# Altium schematic sheet main.SchDoc
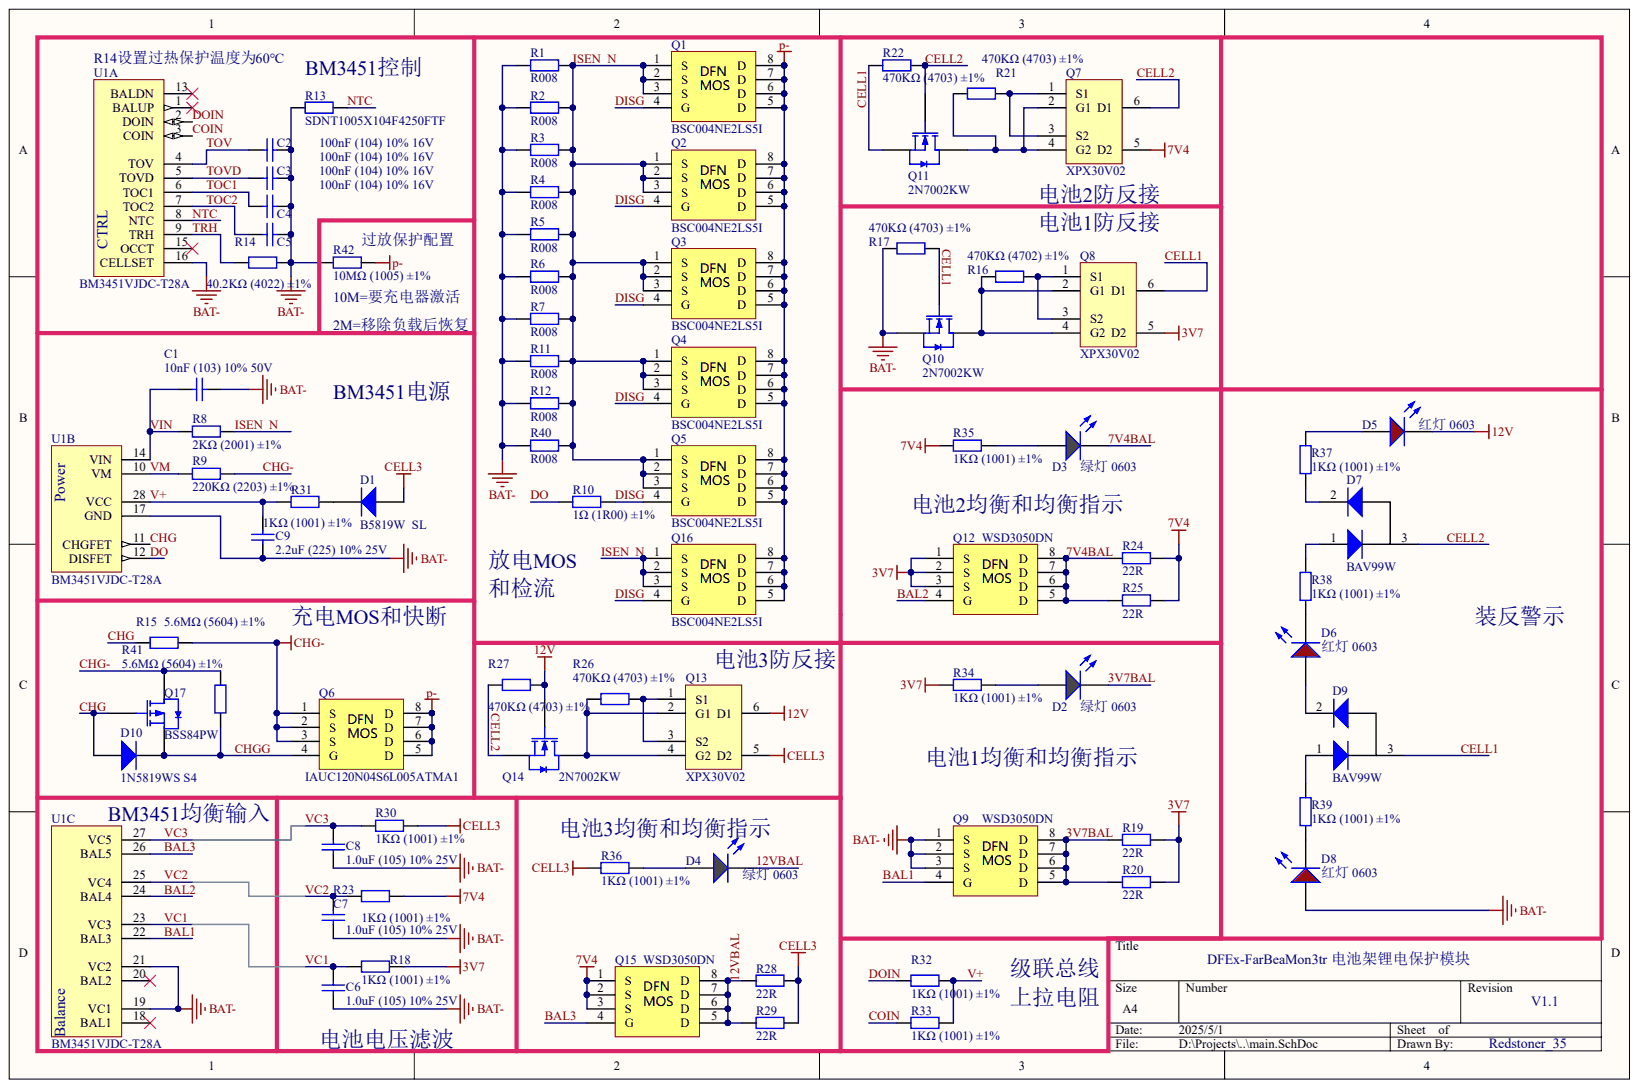
[diagram p0: main.SchDoc]

## Parts
C1  10nF (103) 10% 50V  [0402_C]
C2  100nF (104) 10% 16V  [0402_C]
C3  100nF (104) 10% 16V  [0402_C]
C4  100nF (104) 10% 16V  [0402_C]
C5  100nF (104) 10% 16V  [0402_C]
C6  1.0uF (105) 10% 25V  [0402_C]
C7  1.0uF (105) 10% 25V  [0402_C]
C8  1.0uF (105) 10% 25V  [0402_C]
C9  2.2uF (225) 10% 25V  [0402_C]
D1  B5819W  SL  [SOD-123]
D2  绿灯 0603  Package: 0603  [0603_LED_S1]
D3  绿灯 0603  Package: 0603  [0603_LED_S1]
D4  绿灯 0603  Package: 0603  [0603_LED_S1]
D5  红灯 0603  Package: 0603  [0603_LED_S1]
D6  红灯 0603  [0603_LED_S1]
D7  BAV99W  [SC70-3]
D8  红灯 0603  [0603_LED_S1]
D9  BAV99W  [SC70-3]
D10  1N5819WS S4  [SOD-323]
Q1  BSC004NE2LS5I  [SON/DFN5x6(MOS)]
Q2  BSC004NE2LS5I  [SON/DFN5x6(MOS)]
Q3  BSC004NE2LS5I  [SON/DFN5x6(MOS)]
Q4  BSC004NE2LS5I  [SON/DFN5x6(MOS)]
Q5  BSC004NE2LS5I  [SON/DFN5x6(MOS)]
Q6  IAUC120N04S6L005ATMA1  [SON/DFN5x6(MOS)]
Q7  XPX30V02  [EDFN3x3]
Q8  XPX30V02  [EDFN3x3]
Q9  WSD3050DN  [DFN-8_L3.0-W3.0-P0.65-BL]
Q10  2N7002KW  [SC70-3]
Q11  2N7002KW  [SC70-3]
Q12  WSD3050DN  [DFN-8_L3.0-W3.0-P0.65-BL]
Q13  XPX30V02  [EDFN3x3]
Q14  2N7002KW  [SC70-3]
Q15  WSD3050DN  [DFN-8_L3.0-W3.0-P0.65-BL]
Q16  BSC004NE2LS5I  [SON/DFN5x6(MOS)]
Q17  BSS84PW  [SC70-3]
R1  R008  [1206R]
R2  R008  [1206R]
R3  R008  [1206R]
R4  R008  [1206R]
R5  R008  [1206R]
R6  R008  [1206R]
R7  R008  [1206R]
R8  2KΩ (2001) ±1%  [0402_R]
R9  220KΩ (2203) ±1%  [0402_R]
R10  1Ω (1R00) ±1%  [0402_R]
R11  R008  [1206R]
R12  R008  [1206R]
R13  SDNT1005X104F4250FTF  [0402_R]
R14  40.2KΩ (4022) ±1%  [0402_R]
R15  5.6MΩ (5604) ±1%  [0402_R]
R16  470KΩ (4702) ±1%  [0402_R]
R17  470KΩ (4703) ±1%  [0402_R]
R18  1KΩ (1001) ±1%  [0402_R]
R19  22R  [1210R]
R20  22R  [1210R]
R21  470KΩ (4703) ±1%  [0402_R]
R22  470KΩ (4703) ±1%  [0402_R]
R23  1KΩ (1001) ±1%  [0402_R]
R24  22R  [1210R]
R25  22R  [1210R]
R26  470KΩ (4703) ±1%  [0402_R]
R27  470KΩ (4703) ±1%  [0402_R]
R28  22R  [1210R]
R29  22R  [1210R]
R30  1KΩ (1001) ±1%  [0402_R]
R31  1KΩ (1001) ±1%  [0402_R]
R32  1KΩ (1001) ±1%  [0402_R]
R33  1KΩ (1001) ±1%  [0402_R]
R34  1KΩ (1001) ±1%  [0402_R]
R35  1KΩ (1001) ±1%  [0402_R]
R36  1KΩ (1001) ±1%  [0402_R]
R37  1KΩ (1001) ±1%  [0402_R]
R38  1KΩ (1001) ±1%  [0402_R]
R39  1KΩ (1001) ±1%  [0402_R]
R40  R008  [1206R]
R41  5.6MΩ (5604) ±1%  [0402_R]
R42  10MΩ (1005) ±1%  [0402_R]
U1  BM3451VJDC-T28A  [TSSOP28-BM3451]  (parts A,B,C)

## Nets
3V7: Q8.5(D2) Q12.1(S) Q12.2(S) Q12.3(S) R18.2 R19.1 R20.1 R34.1
7V4: Q7.5(D2) Q15.1(S) Q15.2(S) Q15.3(S) R23.2 R24.1 R25.1 R35.1
12V: D5.K Q13.6(D1) Q14.1 R27.2
BAT-: C1.2 C2.2 C3.2 C4.2 C5.2 C6.1 C7.1 C8.1 C9.1 D8.A Q9.1(S) Q9.2(S) Q9.3(S) Q10.2 R1.2 R2.2 R3.2 R4.2 R5.2 R6.2 R7.2 R11.2 R12.2 R13.2 R14.2 R17.1 R40.2 R42.1 U1.16(CELLSET) U1.17(GND) U1.19(VC1) U1.21(VC2)
CELL3: D1.1 Q13.5(D2) R28.1 R29.1 R30.2 R36.1
P-: Q1.5(D) Q1.6(D) Q1.7(D) Q1.8(D) Q2.5(D) Q2.6(D) Q2.7(D) Q2.8(D) Q3.5(D) Q3.6(D) Q3.7(D) Q3.8(D) Q4.5(D) Q4.6(D) Q4.7(D) Q4.8(D) Q5.5(D) Q5.6(D) Q5.7(D) Q5.8(D) Q6.5(D) Q6.6(D) Q6.7(D) Q6.8(D) Q16.5(D) Q16.6(D) Q16.7(D) Q16.8(D) R42.2
3V7BAL: D2.K Q9.5(D) Q9.6(D) Q9.7(D) Q9.8(D) R19.2 R20.2
7V4BAL: D3.K Q12.5(D) Q12.6(D) Q12.7(D) Q12.8(D) R24.2 R25.2
12VBAL: D4.K Q15.5(D) Q15.6(D) Q15.7(D) Q15.8(D) R28.2 R29.2
BAL1: Q9.4(G) U1.22(BAL3)
BAL2: Q12.4(G) U1.24(BAL4)
BAL3: Q15.4(G) U1.26(BAL5)
CELL1: D9.3 Q8.6(D1) Q10.1 Q11.2 R17.2 R22.1
CELL2: D7.3 Q7.6(D1) Q11.1 Q14.2 R22.2 R27.1
CHG: D10.1 Q17.1 R15.1 U1.11(CHGFET)
CHG-: Q6.1(S) Q6.2(S) Q6.3(S) Q17.3 R9.2 R15.2 R41.2
CHGG: D10.2 Q6.4(G) Q17.2 R41.1
COIN: R33.1 U1.3(COIN)
DISG: Q1.4(G) Q2.4(G) Q3.4(G) Q4.4(G) Q5.4(G) Q16.4(G) R10.2
DO: R10.1 U1.12(DISFET)
DOIN: R32.1 U1.2(DOIN)
ISEN_N: Q1.1(S) Q1.2(S) Q1.3(S) Q2.1(S) Q2.2(S) Q2.3(S) Q3.1(S) Q3.2(S) Q3.3(S) Q4.1(S) Q4.2(S) Q4.3(S) Q5.1(S) Q5.2(S) Q5.3(S) Q16.1(S) Q16.2(S) Q16.3(S) R1.1 R2.1 R3.1 R4.1 R5.1 R6.1 R7.1 R8.2 R11.1 R12.1 R40.1
NTC: R13.1 U1.8(NTC)
TOC1: C4.1 U1.6(TOC1)
TOC2: C5.1 U1.7(TOC2)
TOV: C2.1 U1.4(TOV)
TOVD: C3.1 U1.5(TOVD)
TRH: R14.1 U1.9(TRH)
V+: C9.2 R31.1 R32.2 R33.2 U1.28(VCC)
VC1: C6.2 R18.1 U1.23(VC3)
VC2: C7.2 R23.1 U1.25(VC4)
VC3: C8.2 R30.1 U1.27(VC5)
VIN: C1.1 R8.1 U1.14(VIN)
VM: R9.1 U1.10(VM)
NetD1_2: D1.2 R31.2
NetD2_A: D2.A R34.2
NetD3_A: D3.A R35.2
NetD4_A: D4.A R36.2
NetD5_A: D5.A R37.2
NetD6_A: D6.A D9.2
NetD6_K: D6.K R38.1
NetD7_1: D7.1 R38.2
NetD7_2: D7.2 R37.1
NetD8_K: D8.K R39.1
NetD9_1: D9.1 R39.2
NetQ7_1: Q7.1(S1) Q7.3(S2) R21.2
NetQ7_2: Q7.2(G1) Q7.4(G2) Q11.3 R21.1
NetQ8_1: Q8.1(S1) Q8.3(S2) R16.2
NetQ8_2: Q8.2(G1) Q8.4(G2) Q10.3 R16.1
NetQ13_1: Q13.1(S1) Q13.3(S2) R26.2
NetQ13_2: Q13.2(G1) Q13.4(G2) Q14.3 R26.1
N00022:

Not connected: U1 1(BALUP) 13(BALDN) 15(OCCT) 18(BAL1) 20(BAL2)

## Library data of the parts
C1 = 0402 10nF (103) 10% 50V: 贴片电容; Component Kind: Standard; ComponentLink1Description: 供应商链接; ComponentLink1URL: http://www.szlcsc.com/product/details_15869.html; Library Name: Miscellaneous Devices LC.IntLib; Package: 0402; Supplier: LC; SuppliersPartNumber: C15195
C2-C5 = 0402 100nF (104) 10% 16V: 贴片电容; Component Kind: Standard; ComponentLink1Description: 供应商链接; ComponentLink1URL: http://www.szlcsc.com/product/details_1877.html; Library Name: Miscellaneous Devices LC.IntLib; Package: 0402; Supplier: LC; SuppliersPartNumber: C1525
C6-C8 = 0402 1.0uF (105) 10% 25V: 贴片电容; Component Kind: Standard; ComponentLink1Description: 供应商链接; ComponentLink1URL: http://www.szlcsc.com/product/details_53938.html; Library Name: Miscellaneous Devices LC.IntLib; Package: 0402; Supplier: LC; SuppliersPartNumber: C52923
C9 = 0402 2.2nF (222) 10% 50V: 贴片电容; Component Kind: Standard; ComponentLink1Description: 供应商链接; ComponentLink1URL: http://www.szlcsc.com/product/details_1883.html; Library Name: Miscellaneous Devices LC.IntLib; Package: 0402; Supplier: LC; SuppliersPartNumber: C1531
D1 = SOD-123 B5819W  SL: 肖特基二极管; Component Kind: Standard; ComponentLink1Description: 供应商链接; ComponentLink1URL: http://www.szlcsc.com/product/details_9093.html; Library Name: Miscellaneous Devices LC.IntLib; Package: SOD-123; Supplier: LC; SuppliersPartNumber: C8598
D2-D4 = 0603 绿灯: 发光二极管; Component Kind: Standard; ComponentLink1Description: 供应商链接; ComponentLink1URL: http://www.szlcsc.com/product/details_73152.html; Library Name: Miscellaneous Devices LC.IntLib; Supplier: LC; SuppliersPartNumber: C72043
D5 D6 D8 = 0603 红灯: 发光二极管; Component Kind: Standard; ComponentLink1Description: 供应商链接; ComponentLink1URL: http://www.szlcsc.com/product/details_2659.html; Library Name: Miscellaneous Devices LC.IntLib; Supplier: LC; SuppliersPartNumber: C2286
  D6 D8: Package: 0603
D7 D9 = SOT-23 BAV99 A7W: 开关二极管; Component Kind: Standard; ComponentLink1Description: 供应商链接; ComponentLink1URL: http://www.szlcsc.com/product/details_2877.html; Library Name: Miscellaneous Devices LC.IntLib; Package: SOT-23; Supplier: LC; SuppliersPartNumber: C2500
D10 = SOD-323 1N4148WS T4: 二极管; Component Kind: Standard; ComponentLink1Description: 供应商链接; ComponentLink1URL: http://www.szlcsc.com/product/details_2485.html; Library Name: Miscellaneous Devices LC.IntLib; Package: SOD-323; Supplier: LC; SuppliersPartNumber: C2128
Q1-Q5 Q16 = SON/DFN5x6 MOS: 0.4mR OptiMOS 5 MOSFET; Component Kind: Standard; Library Name: custom.SchLib
Q6 = SON/DFN5x6 MOS: 0.5mR 120A OptiMOS 6 Automotive grade MOSFET,SSO8(5x6) Package; Component Kind: Standard; Library Name: custom.SchLib
Q7 Q8 Q13 = XPX10H03RX: 双N沟道MOSFET, DFN3x3 30V 40A 10mΩ; Component Kind: Standard; Library Name: custom.SchLib
Q9 Q12 Q15 = SON/DFN5x6 MOS: PDFN3x3 20V 50A 5.8mΩ N-Ch MOSFET; Component Kind: Standard; Library Name: custom.SchLib
Q10 Q11 Q14 = 2N7002 7002: 单N沟道MOSFET(场效应管); Component Kind: Standard; ComponentLink1Description: 供应商链接; ComponentLink1URL: http://www.szlcsc.com/product/details_9040.html; Library Name: Miscellaneous Devices LC.IntLib; Package: SOT-323; Supplier: LC; SuppliersPartNumber: C???????
Q17 = SI2301CDS-T1-GE3: MOS(场效应管); Component Kind: Standard; ComponentLink1Description: 供应商链接; ComponentLink1URL: http://www.szlcsc.com/product/details_11030.html; Library Name: Miscellaneous Devices LC.IntLib; Package: SOT-23(SOT-23-3); Supplier: LC; SuppliersPartNumber: C10487
R1-R7 R11 R12 R19 R20 R24 R25 R28 R29 R40 = Res: Resistor; Component Kind: Standard; LatestRevisionDate: 17-Jul-2002; LatestRevisionNote: Re-released for DXP Platform.; PackageDescription: Axial Device, Thru-Hole; 2 Leads; 0.4 in Pin Spacing; PackageReference: AXIAL-0.4; Published: 8-Jun-2000; Library Name: 山猫的集成库20190318.IntLib; Publisher: Altium Limited
  R1-R7 R11 R12 R40: Value: R008
  R19 R20 R24 R25 R28 R29: Value: 22R
R8 = 0402 2KΩ (2001) 1%: 贴片电阻; Component Kind: Standard; ComponentLink1Description: 供应商链接; ComponentLink1URL: http://www.szlcsc.com/product/details_4516.html; Library Name: Miscellaneous Devices LC.IntLib; Package: 0402; Supplier: LC; SuppliersPartNumber: C4109
R9 = 0402 220KΩ (2203) 1%: 贴片电阻; Component Kind: Standard; ComponentLink1Description: 供应商链接; ComponentLink1URL: http://www.szlcsc.com/product/details_26510.html; Library Name: Miscellaneous Devices LC.IntLib; Package: 0402; Supplier: LC; SuppliersPartNumber: C25767
R10 = 0402 1Ω (1R00) 1%: 贴片电阻; Component Kind: Standard; ComponentLink1Description: 供应商链接; ComponentLink1URL: http://www.szlcsc.com/product/details_25829.html; Library Name: Miscellaneous Devices LC.IntLib; Package: 0402; Supplier: LC; SuppliersPartNumber: C25086
R13 = 0402 100KΩ (1003) 1%: 贴片NTC热敏电阻 100KΩ (1003) ±1% B4250; Component Kind: Standard; ComponentLink1Description: 供应商链接; ComponentLink1URL: http://www.szlcsc.com/product/details_26484.html; Library Name: Miscellaneous Devices LC.IntLib; Package: 0402; Supplier: LC; SuppliersPartNumber: C25741
R14 = 0402 56KΩ (5602) 1%: 贴片电阻; Component Kind: Standard; ComponentLink1Description: 供应商链接; ComponentLink1URL: http://www.szlcsc.com/product/details_26539.html; Library Name: Miscellaneous Devices LC.IntLib; Package: 0402; Supplier: LC; SuppliersPartNumber: C25796
R15 R41 = 0402 1MΩ (1004) 1%: 贴片电阻; Component Kind: Standard; ComponentLink1Description: 供应商链接; ComponentLink1URL: http://www.szlcsc.com/product/details_26826.html; Library Name: Miscellaneous Devices LC.IntLib; Package: 0402; Supplier: LC; SuppliersPartNumber: C26083
R16 = 0402 20KΩ (2002) 1%: 贴片电阻; Component Kind: Standard; ComponentLink1Description: 供应商链接; ComponentLink1URL: http://www.szlcsc.com/product/details_26508.html; Library Name: Miscellaneous Devices LC.IntLib; Package: 0402; Supplier: LC; SuppliersPartNumber: C25765
R17 R21 R22 R26 R27 = 0402 470KΩ (4703) 1%: 贴片电阻; Component Kind: Standard; ComponentLink1Description: 供应商链接; ComponentLink1URL: http://www.szlcsc.com/product/details_26533.html; Library Name: Miscellaneous Devices LC.IntLib; Package: 0402; Supplier: LC; SuppliersPartNumber: C25790
R18 R23 R30-R39 = 0402 1KΩ (1001) 1%: 贴片电阻; Component Kind: Standard; ComponentLink1Description: 供应商链接; ComponentLink1URL: http://www.szlcsc.com/product/details_12256.html; Library Name: Miscellaneous Devices LC.IntLib; Package: 0402; Supplier: LC; SuppliersPartNumber: C11702
R42 = 0402 10MΩ (1005) 1%: 贴片电阻; Component Kind: Standard; ComponentLink1Description: 供应商链接; ComponentLink1URL: http://www.szlcsc.com/product/details_26825.html; Library Name: Miscellaneous Devices LC.IntLib; Package: 0402; Supplier: LC; SuppliersPartNumber: C26082
U1 = BM3451-T28A: 2-5串全功能锂电池保护IC，支持级联功能; Component Kind: Standard; Library Name: custom.SchLib
